# Altium schematic sheet pultboard_connector.SchDoc(pultboard_connector)
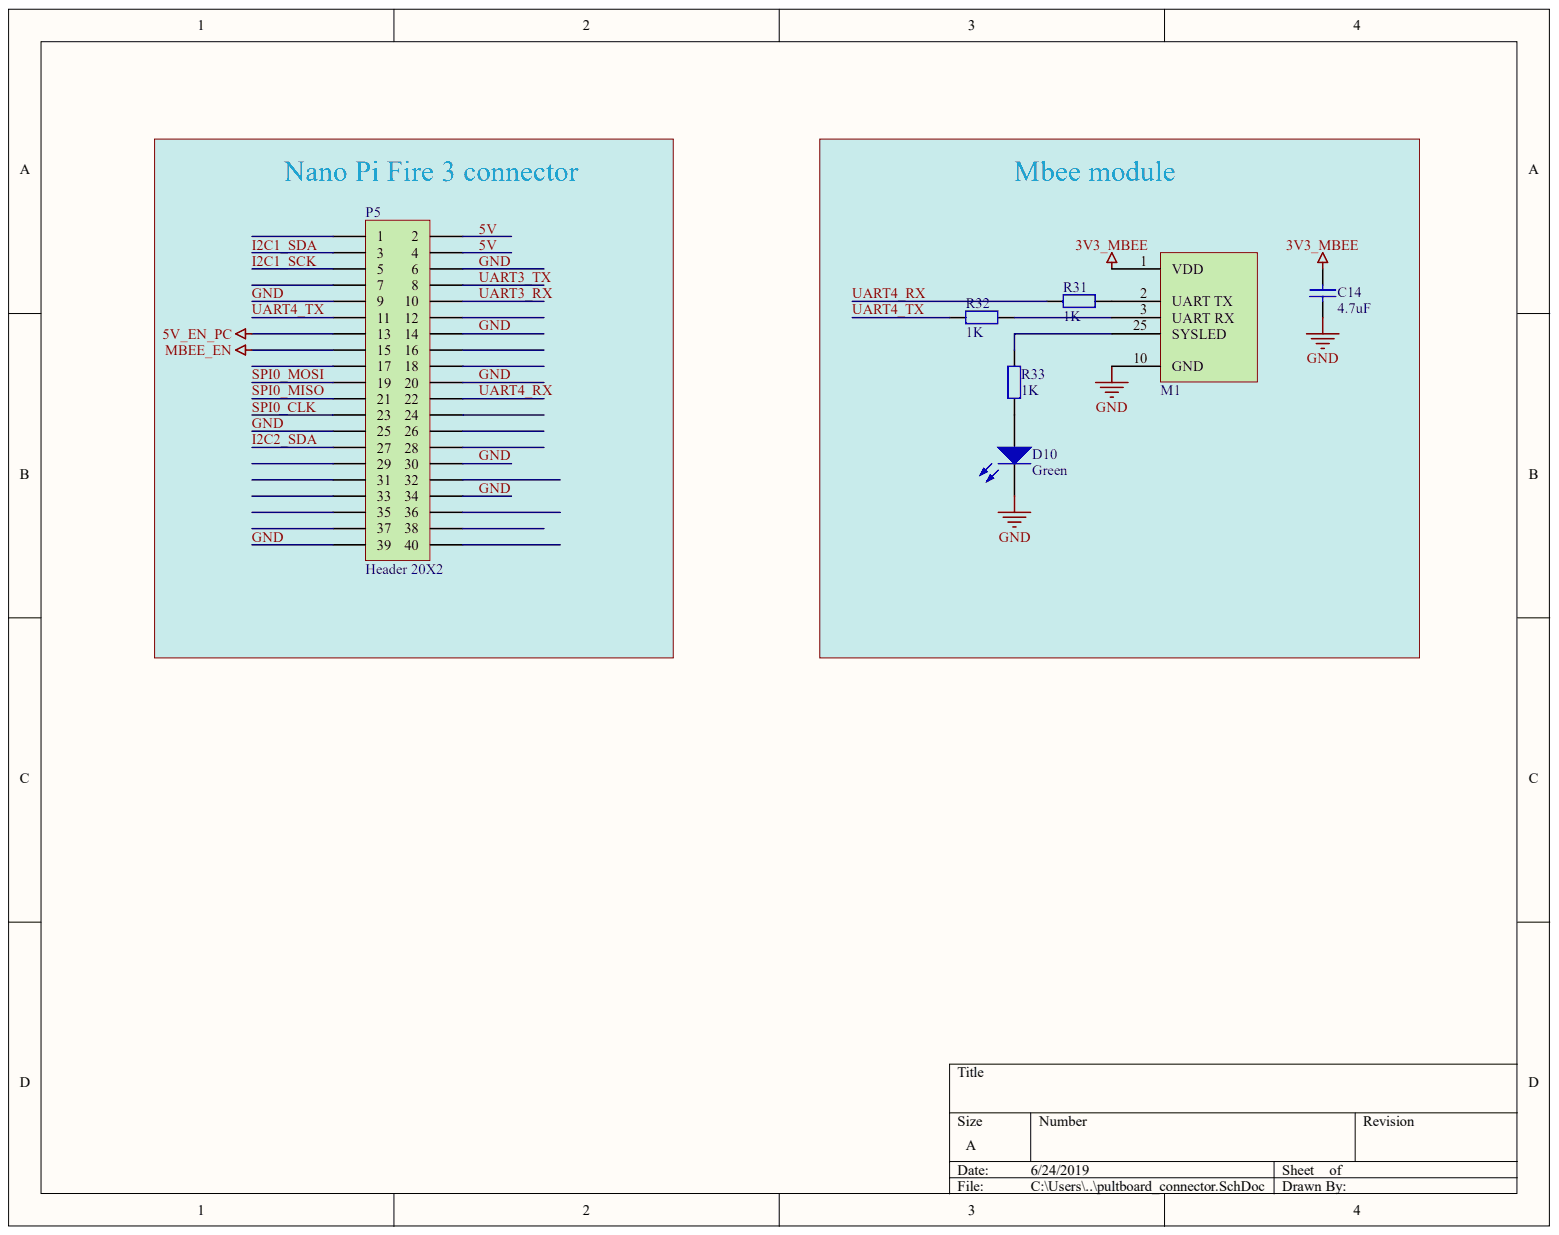
[diagram p0: pultboard_connector.SchDoc]

## Parts
C14  value 4.7uF  [0603]  (hidden comment: Cap)
D10  Green  [D0603]
M1  MBee  [MBee]  (value not drawn)
P5  Header 20X2  [HDR2X20]
R31  value 1K  [0603]  (hidden comment: Res)
R32  value 1K  [0603]  (hidden comment: Res)
R33  value 1K  [0603]  (hidden comment: Res)

## Nets
3V3_MBEE: C14.2 M1.1(VDD)
5V_EN_PC: P5.13
MBEE_EN: P5.15
5V: P5.2 P5.4
GND: C14.1 D10.2 M1.10(GND) P5.6 P5.9 P5.14 P5.20 P5.25 P5.30 P5.34 P5.39
I2C1_SCK: P5.5
I2C1_SDA: P5.3
I2C2_SDA: P5.27
SPI0_CLK: P5.23
SPI0_MISO: P5.21
SPI0_MOSI: P5.19
UART3_RX: P5.10
UART3_TX: P5.8
UART4_RX: P5.22 R31.1
UART4_TX: P5.11 R32.1
NetD10_1: D10.1 R33.1
NetM1_2: M1.2(UART_TX) R31.2
NetM1_3: M1.3(UART_RX) R32.2
NetM1_25: M1.25(SYSLED) R33.2

Not connected: P5 1 7 12 16 17 18 24 26 28 29 31 32 33 35 36 37 38 40

## Library data of the parts
C14 = GRM188R60J475KE19D@MURATA: Capacitor; Database Table Name: Capacitor ceramic; Tolerance: 10%; Voltage rated: 6V; Component Kind: Standard; Library Name: passive.DbLib; Link: http://www.elitan.ru/price/item605637; Package: 0603; Part Number: GRM188R60J475KE19D@MURATA; Supplier: elitan.ru
D10 = LTST-C191KGKT@LITEON: Database Table Name: Led; Component Kind: Standard; Library Name: discrete.DbLib; Part Number: LTST-C191KGKT@LITEON; Supplier: elitan.ru
M1 = Mbee: Database Table Name: Modules; Component Kind: Standard; Description: MBee; Library Name: connectors.DbLib; Part Number: Mbee
P5 = Header 20X2: Header, 20-Pin, Dual row; Component Kind: Standard; LatestRevisionDate: 17-Jul-2002; LatestRevisionNote: Re-released for DXP Platform.; Library Name: Miscellaneous Connectors.IntLib; Signal Integrity: Connector; Publisher: Altium Limited
R31-R33 = R_00033: Resistor; Tolerance: 5%; Component Kind: Standard; Database Table Name: Resistor 0603; Library Name: passive.DbLib; Package: 0603; Part Number: R_00033; Supplier: elitan.ru
